# Altium schematic sheet FPGA_RAM.SchDoc(FPGA_RAM)
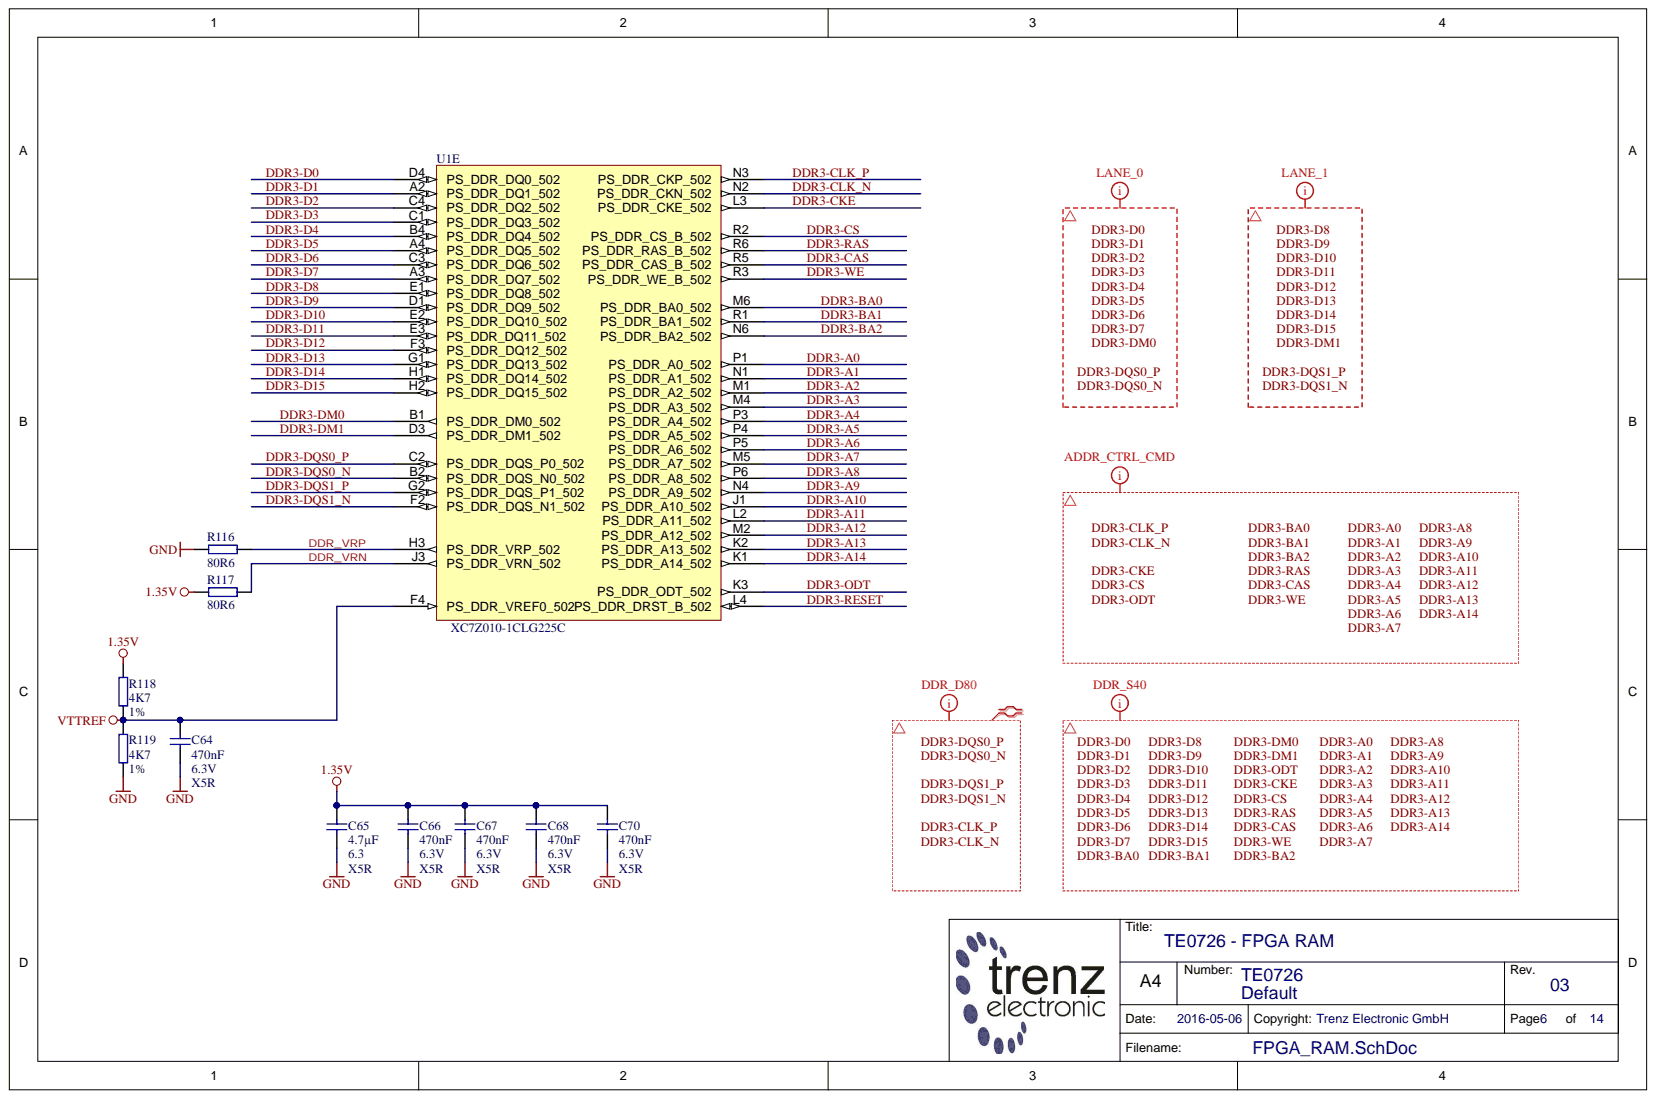
[diagram p0: FPGA_RAM.SchDoc]

## Parts
C64  470nF  Technology: X5R  Voltage: 6.3V  [CAPC0603X33N]
C65  4.7µF  Technology: X5R  Voltage: 6.3  [CAPC1005X60N]
C66  470nF  Technology: X5R  Voltage: 6.3V  [CAPC0603X33N]
C67  470nF  Technology: X5R  Voltage: 6.3V  [CAPC0603X33N]
C68  470nF  Technology: X5R  Voltage: 6.3V  [CAPC0603X33N]
C70  470nF  Technology: X5R  Voltage: 6.3V  [CAPC0603X33N]
R116  80R6  [RESC1005X40N]
R117  80R6  [RESC1005X40N]
R118  4K7  Tolerance: 1%  [RESC1005X40N]
R119  4K7  Tolerance: 1%  [RESC1005X40N]
U1  XC7Z010-1CLG225C  [BGA225C80P15X15_1300X1300X150B40N]  (part E)

## Nets
1.35V: C65.1 C66.1 C67.1 C68.2 C70.1 R117.1 R118.1
GND: C64.2 C65.2 C66.2 C67.2 C68.1 C70.2 R116.1 R119.2
VTTREF: C64.1 R118.2 R119.1 U1.F4(PS_DDR_VREF0_502)
DDR3-A0: U1.P1(PS_DDR_A0_502)
DDR3-A1: U1.N1(PS_DDR_A1_502)
DDR3-A2: U1.M1(PS_DDR_A2_502)
DDR3-A3: U1.M4(PS_DDR_A3_502)
DDR3-A4: U1.P3(PS_DDR_A4_502)
DDR3-A5: U1.P4(PS_DDR_A5_502)
DDR3-A6: U1.P5(PS_DDR_A6_502)
DDR3-A7: U1.M5(PS_DDR_A7_502)
DDR3-A8: U1.P6(PS_DDR_A8_502)
DDR3-A9: U1.N4(PS_DDR_A9_502)
DDR3-A10: U1.J1(PS_DDR_A10_502)
DDR3-A11: U1.L2(PS_DDR_A11_502)
DDR3-A12: U1.M2(PS_DDR_A12_502)
DDR3-A13: U1.K2(PS_DDR_A13_502)
DDR3-A14: U1.K1(PS_DDR_A14_502)
DDR3-BA0: U1.M6(PS_DDR_BA0_502)
DDR3-BA1: U1.R1(PS_DDR_BA1_502)
DDR3-BA2: U1.N6(PS_DDR_BA2_502)
DDR3-CAS: U1.R5(PS_DDR_CAS_B_502)
DDR3-CKE: U1.L3(PS_DDR_CKE_502)
DDR3-CLK_N: U1.N2(PS_DDR_CKN_502)
DDR3-CLK_P: U1.N3(PS_DDR_CKP_502)
DDR3-CS: U1.R2(PS_DDR_CS_B_502)
DDR3-D0: U1.D4(PS_DDR_DQ0_502)
DDR3-D1: U1.A2(PS_DDR_DQ1_502)
DDR3-D2: U1.C4(PS_DDR_DQ2_502)
DDR3-D3: U1.C1(PS_DDR_DQ3_502)
DDR3-D4: U1.B4(PS_DDR_DQ4_502)
DDR3-D5: U1.A4(PS_DDR_DQ5_502)
DDR3-D6: U1.C3(PS_DDR_DQ6_502)
DDR3-D7: U1.A3(PS_DDR_DQ7_502)
DDR3-D8: U1.E1(PS_DDR_DQ8_502)
DDR3-D9: U1.D1(PS_DDR_DQ9_502)
DDR3-D10: U1.E2(PS_DDR_DQ10_502)
DDR3-D11: U1.E3(PS_DDR_DQ11_502)
DDR3-D12: U1.F3(PS_DDR_DQ12_502)
DDR3-D13: U1.G1(PS_DDR_DQ13_502)
DDR3-D14: U1.H1(PS_DDR_DQ14_502)
DDR3-D15: U1.H2(PS_DDR_DQ15_502)
DDR3-DM0: U1.B1(PS_DDR_DM0_502)
DDR3-DM1: U1.D3(PS_DDR_DM1_502)
DDR3-DQS0_N: U1.B2(PS_DDR_DQS_N0_502)
DDR3-DQS0_P: U1.C2(PS_DDR_DQS_P0_502)
DDR3-DQS1_N: U1.F2(PS_DDR_DQS_N1_502)
DDR3-DQS1_P: U1.G2(PS_DDR_DQS_P1_502)
DDR3-ODT: U1.K3(PS_DDR_ODT_502)
DDR3-RAS: U1.R6(PS_DDR_RAS_B_502)
DDR3-RESET: U1.L4(PS_DDR_DRST_B_502)
DDR3-WE: U1.R3(PS_DDR_WE_B_502)
DDR_VRN: R117.2 U1.J3(PS_DDR_VRN_502)
DDR_VRP: R116.2 U1.H3(PS_DDR_VRP_502)
N00276:
N00277:
N00278:
N00279:
N00280:
N00281:

## Library data of the parts
C64 C66-C68 C70 = 24921: KON 470nF X5R 6.3V SMD 20%; Database Table Name: C_0603_0201; Mixed: SMT; Preferred: Yes; Value: 470n; AN: 24921; Case: 0603 (0201); Component Kind: Standard; Library Name: TE.DbLib; Tolerance: 20%
C65 = 24719: KON 4.7µF 6.3V X5R SMD 20%; Database Table Name: C_1005_0402; Mixed: SMT; Preferred: Yes; Value: 4.7u; AN: 24719; Case: 1005 (0402); Component Kind: Standard; Library Name: TE.DbLib; Tolerance: 20%
R116 R117 = 25100: WID SMD 80R6 1% 0,063W; Database Table Name: R_1005_0402; Mixed: SMT; Preferred: Yes; Value: 80.6; AN: 25100; Case: 1005 (0402); Component Kind: Standard; Library Name: TE.DbLib; Technology: TK100; Tolerance: 1%
R118 R119 = 22585: WID SMD 4K7 1% 0,063W; Database Table Name: R_1005_0402; Mixed: SMT; Preferred: Yes; Value: 4.7K; AN: 22585; Case: 1005 (0402); Component Kind: Standard; Library Name: TE.DbLib; Technology: TK100
U1 = 26308: Database Table Name: PROG_LOGIC; Mixed: SMT; Preferred: Yes; AN: 26308; Case: CLG225; Component Kind: Standard; Description: XC7Z010-1CLG225C; H-ART: XC7Z010-1CLG225C; Library Name: TE.DbLib; Trenz-Stock: Yes; HelpURL: B:\AD\adlib\datasheet\XC7\Zynq
